# Altium schematic sheet FPGA_Bank_2_3_I2C.SchDoc
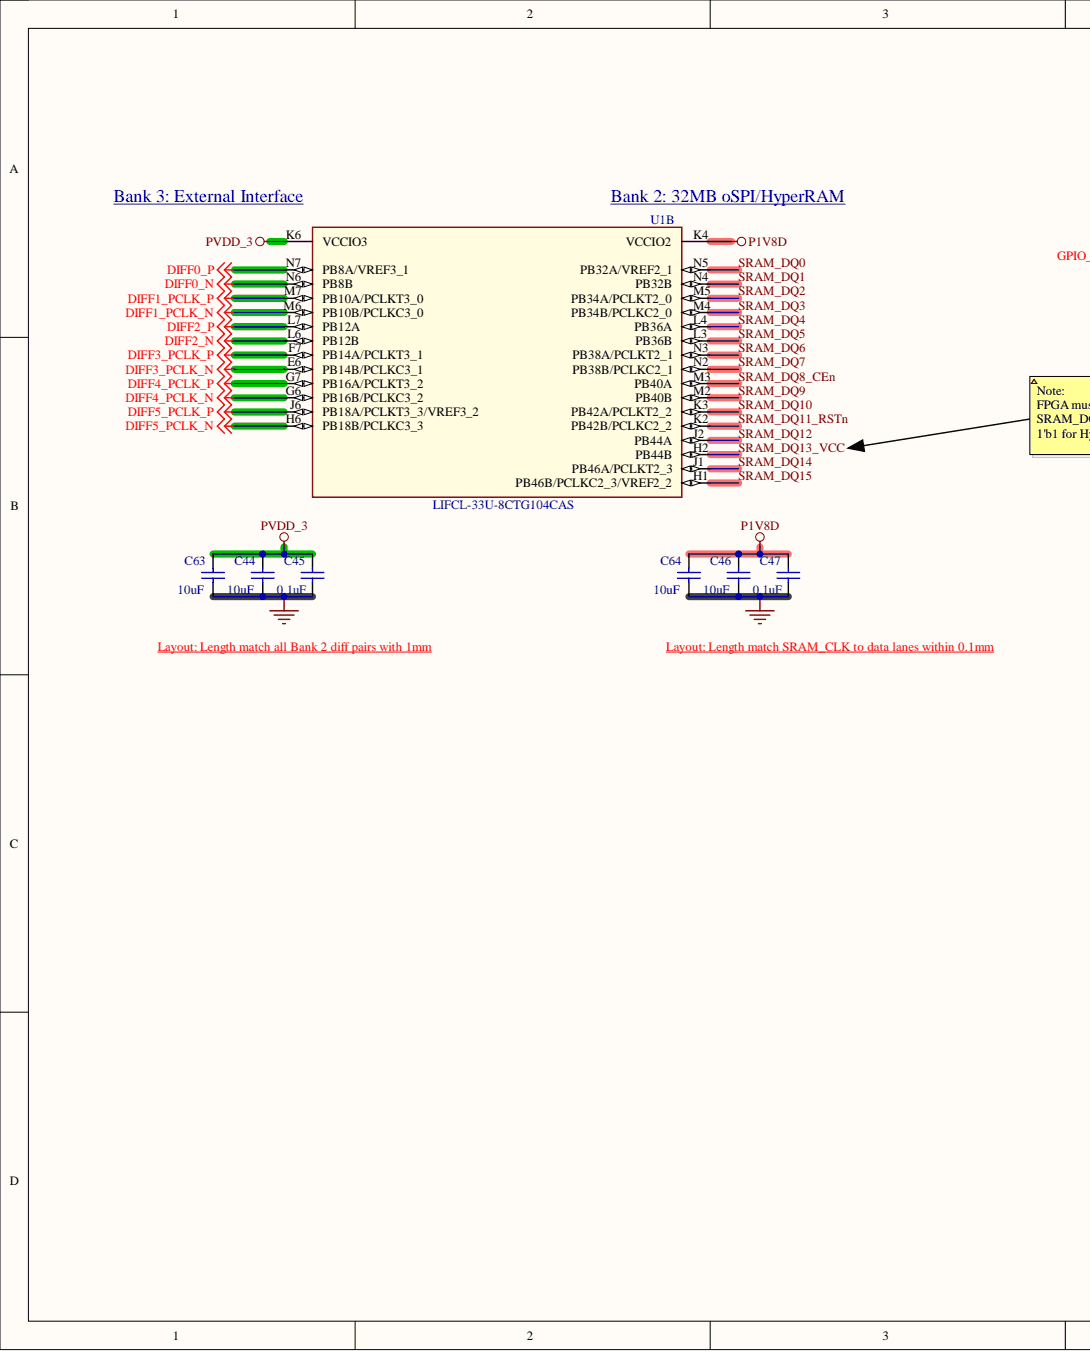
[diagram p0: FPGA_Bank_2_3_I2C.SchDoc, left]
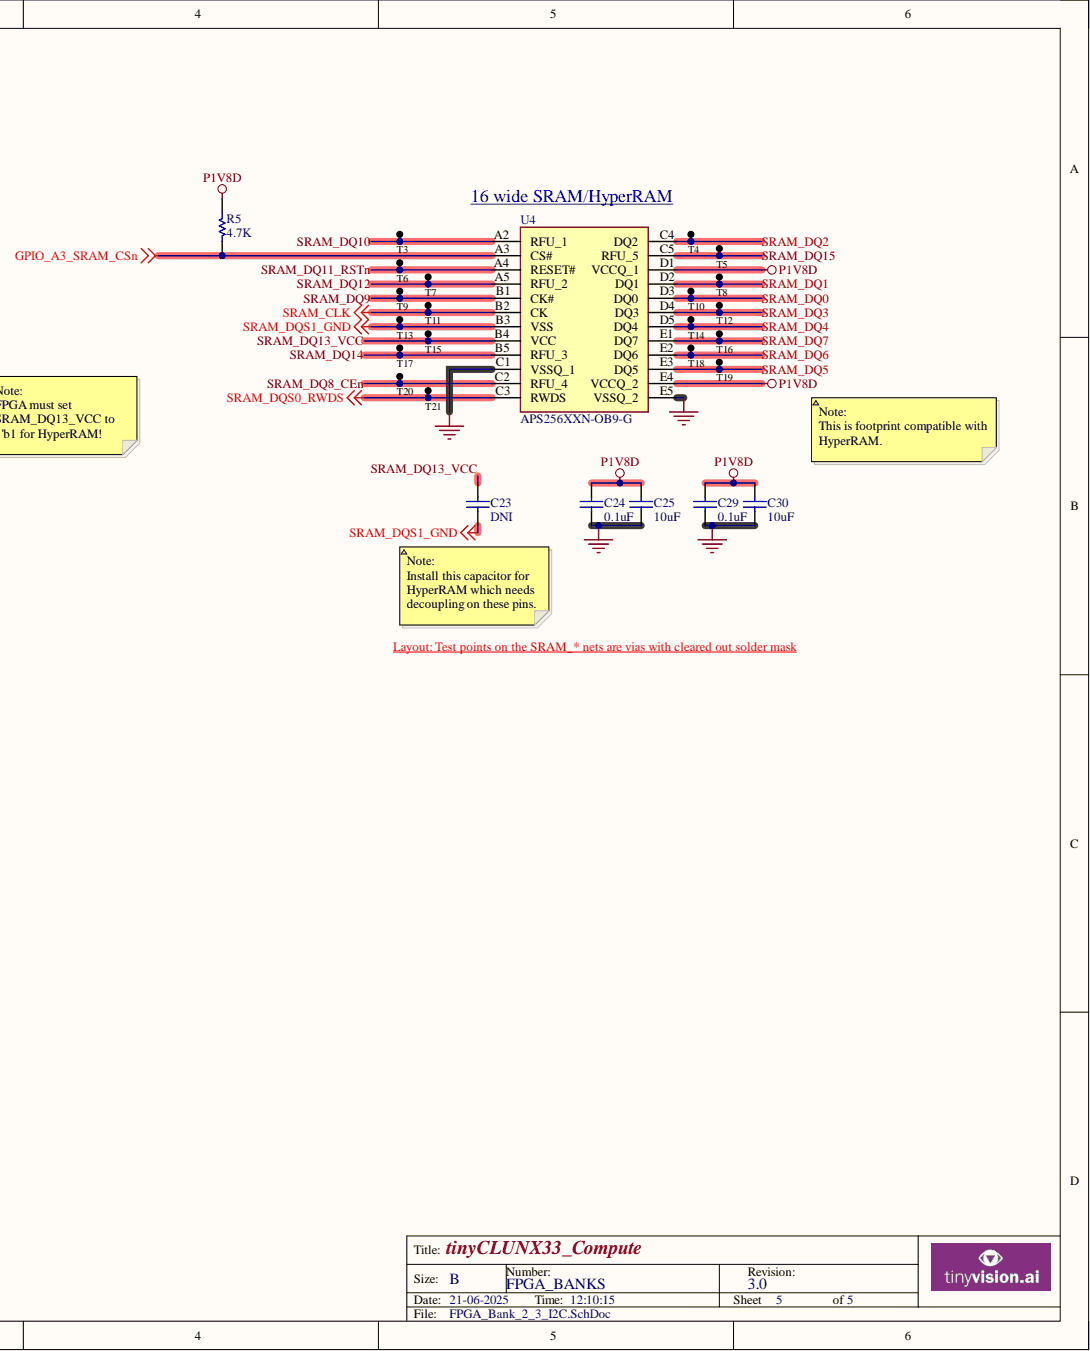
[diagram p1: FPGA_Bank_2_3_I2C.SchDoc, right]

## Parts
C23  DNI  [CAPC0603X33N]
C24  0.1uF  [CAPC0603X33N]
C25  10uF  [CAPC1005X55USR]
C29  0.1uF  [CAPC0603X33N]
C30  10uF  [CAPC1005X55USR]
C44  10uF  [CAPC1005X55USR]
C45  0.1uF  [CAPC0603X33N]
C46  10uF  [CAPC1005X55USR]
C47  0.1uF  [CAPC0603X33N]
C63  10uF  [CAPC1005X55USR]
C64  10uF  [CAPC1005X55USR]
R5  4.7K  [RESC1005X40USR]
T3  TP_S  [TP 20mil]  (value not drawn)  (no BOM)
T4  TP_S  [TP 20mil]  (value not drawn)  (no BOM)
T5  TP_S  [TP 20mil]  (value not drawn)  (no BOM)
T6  TP_S  [TP 20mil]  (value not drawn)  (no BOM)
T7  TP_S  [TP 20mil]  (value not drawn)  (no BOM)
T8  TP_S  [TP 20mil]  (value not drawn)  (no BOM)
T9  TP_S  [TP 20mil]  (value not drawn)  (no BOM)
T10  TP_S  [TP 20mil]  (value not drawn)  (no BOM)
T11  TP_S  [TP 20mil]  (value not drawn)  (no BOM)
T12  TP_S  [TP 20mil]  (value not drawn)  (no BOM)
T13  TP_S  [TP 20mil]  (value not drawn)  (no BOM)
T14  TP_S  [TP 20mil]  (value not drawn)  (no BOM)
T15  TP_S  [TP 20mil]  (value not drawn)  (no BOM)
T16  TP_S  [TP 20mil]  (value not drawn)  (no BOM)
T17  TP_S  [TP 20mil]  (value not drawn)  (no BOM)
T18  TP_S  [TP 20mil]  (value not drawn)  (no BOM)
T19  TP_S  [TP 20mil]  (value not drawn)  (no BOM)
T20  TP_S  [TP 20mil]  (value not drawn)  (no BOM)
T21  TP_S  [TP 20mil]  (value not drawn)  (no BOM)
U1  LIFCL-33U-8CTG104CAS  [BGA104C65P8X13_550X850X100]  (part B)
U4  APS256XXN-OB9-G  [BGA24C100P5X5_800X600X120N]

## Nets
DIFF0_N: U1.N6(PB8B)
DIFF0_P: U1.N7(PB8A/VREF3_1)
DIFF1_PCLK_N: U1.M6(PB10B/PCLKC3_0)
DIFF1_PCLK_P: U1.M7(PB10A/PCLKT3_0)
DIFF2_N: U1.L6(PB12B)
DIFF2_P: U1.L7(PB12A)
DIFF3_PCLK_N: U1.E6(PB14B/PCLKC3_1)
DIFF3_PCLK_P: U1.F7(PB14A/PCLKT3_1)
DIFF4_PCLK_N: U1.G6(PB16B/PCLKC3_2)
DIFF4_PCLK_P: U1.G7(PB16A/PCLKT3_2)
DIFF5_PCLK_N: U1.H6(PB18B/PCLKC3_3)
DIFF5_PCLK_P: U1.J6(PB18A/PCLKT3_3/VREF3_2)
GND: C24.1 C25.1 C29.1 C30.1 C44.1 C45.1 C46.1 C47.1 C63.1 C64.1 U4.C1(VSSQ_1) U4.E5(VSSQ_2)
GPIO_A3_SRAM_CSn: R5.1 U4.A3(CS#)
P1V8D: C24.2 C25.2 C29.2 C30.2 C46.2 C47.2 C64.2 R5.2 U1.K4(VCCIO2) U4.D1(VCCQ_1) U4.E4(VCCQ_2)
PVDD_3: C44.2 C45.2 C63.2 U1.K6(VCCIO3)
SRAM_CLK: T11.1 U4.B2(CK)
SRAM_DQS0_RWDS: T21.1 U4.C3(RWDS)
SRAM_DQS1_GND: C23.1 T13.1 U4.B3(VSS)
SRAM_DQ0: T10.1 U1.N5(PB32A/VREF2_1) U4.D3(DQ0)
SRAM_DQ1: T8.1 U1.N4(PB32B) U4.D2(DQ1)
SRAM_DQ2: T4.1 U1.M5(PB34A/PCLKT2_0) U4.C4(DQ2)
SRAM_DQ3: T12.1 U1.M4(PB34B/PCLKC2_0) U4.D4(DQ3)
SRAM_DQ4: T14.1 U1.L4(PB36A) U4.D5(DQ4)
SRAM_DQ5: T19.1 U1.L3(PB36B) U4.E3(DQ5)
SRAM_DQ6: T18.1 U1.N3(PB38A/PCLKT2_1) U4.E2(DQ6)
SRAM_DQ7: T16.1 U1.N2(PB38B/PCLKC2_1) U4.E1(DQ7)
SRAM_DQ8_CEn: T20.1 U1.M3(PB40A) U4.C2(RFU_4)
SRAM_DQ9: T9.1 U1.M2(PB40B) U4.B1(CK#)
SRAM_DQ10: T3.1 U1.K3(PB42A/PCLKT2_2) U4.A2(RFU_1)
SRAM_DQ11_RSTn: T6.1 U1.K2(PB42B/PCLKC2_2) U4.A4(RESET#)
SRAM_DQ12: T7.1 U1.J2(PB44A) U4.A5(RFU_2)
SRAM_DQ13_VCC: C23.2 T15.1 U1.H2(PB44B) U4.B4(VCC)
SRAM_DQ14: T17.1 U1.J1(PB46A/PCLKT2_3) U4.B5(RFU_3)
SRAM_DQ15: T5.1 U1.H1(PB46B/PCLKC2_3/VREF2_2) U4.C5(RFU_5)

## Library data of the parts
C23 C24 C29 C45 C47 = CAP CER 0.1UF 10V X7S 0201: CAP CER 0.1UF 10V X5R 0201; Manufacturer: Murata Electronics; Manufacturer Part Number: GRM033R61A104KE15D; Component Kind: Standard; Height: 0.33mm; Library Name: Smart Camera v2.SCHLIB; Package: 0201; Supplier 1: Digi-Key; Supplier Part Number 1: 490-5881-1-ND; value: 0.1uF
C25 C30 C44 C46 C63 C64 = Cap_10uF_X5R_20%_10V_0402: CAP CER 10UF 10V X5R 0402; Manufacturer: Samsung Electro-Mechanics; Manufacturer Part Number: CL05A106MP5NUNC; Part #: E-CAP-042; Component Kind: Standard; ComponentLink1Description: New link; Height: 0.55mm; Package: 0402; Supplier 1: Digi-Key; Supplier Part Number 1: 1276-1450-1-ND; value: 10uF; Library Name: tinyCLUNX33_Compute.SCHLIB
R5 = RES 4.7K OHM 1% 1/20W 0201: RES 4.7K OHM 1% 1/16W 0402; Manufacturer: Yageo; Manufacturer Part Number: RC0402FR-074K7L; Component Kind: Standard; Height: 0.4mm; Library Name: Smart Camera v2.SCHLIB; Package: 0402; Supplier 1: Digi-Key; Supplier Part Number 1: 311-4.7KLRCT-ND; value: 4.7K
T3-T21 = TP_S: test point; Library Name: MainLIB.SCHLIB
U1 = LIFCL-33U-8CTG104CAS: Manufacturer: LATTICE; Component Kind: Standard; Library Name: NX Module.SCHLIB; Manufacturer Part Number: LIFCL-33U-8CTG104CAS
U4 = S27KS0641DPBHI020: IC PSRAM 64MBIT PARALLEL 24FBGA; Manufacturer: AP Memory; Component Kind: Standard; Datasheet Link: http://www.cypress.com/file/183506/download; Height: 1mm; Library Name: AiC.SCHLIB; Manufacturer Part Number: APS256XXN-OB9-G; Supplier 1: Arrow; Supplier Part Number 1: S27KS0641DPBHI020
